# Altium schematic sheet MECHANICAL.SchDoc(MECHANICAL)
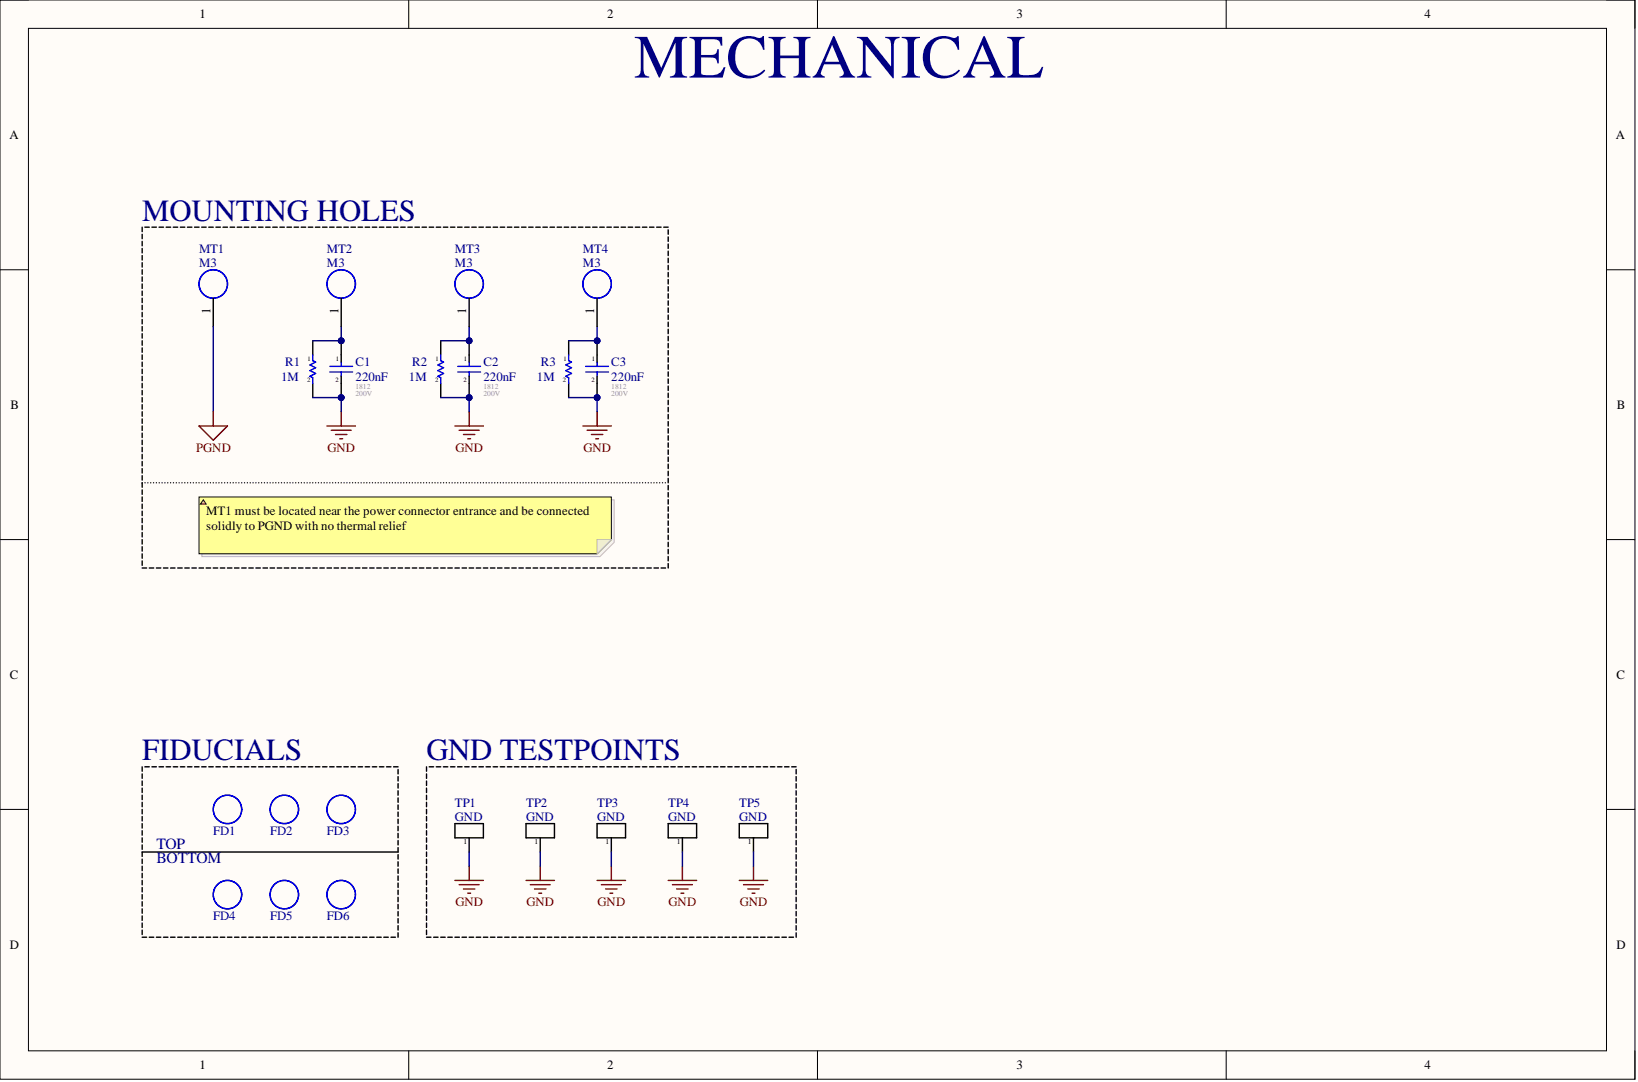
[diagram p0: MECHANICAL.SchDoc]

## Parts
C1  220nF  Voltage - Rated: 200V  [1812]
C2  220nF  Voltage - Rated: 200V  [1812]
C3  220nF  Voltage - Rated: 200V  [1812]
FD1  FIDUCIAL  [FIDUCIAL]  (no BOM)
FD2  FIDUCIAL  [FIDUCIAL]  (no BOM)
FD3  FIDUCIAL  [FIDUCIAL]  (no BOM)
FD4  FIDUCIAL  [FIDUCIAL]  (no BOM)
FD5  FIDUCIAL  [FIDUCIAL]  (no BOM)
FD6  FIDUCIAL  [FIDUCIAL]  (no BOM)
MT1  M3  [MTH_M3]
MT2  M3  [MTH_M3]
MT3  M3  [MTH_M3]
MT4  M3  [MTH_M3]
R1  1M  [RES_0402]
R2  1M  [RES_0402]
R3  1M  [RES_0402]
TP1  GND  [TP_HOLE]  (no BOM)
TP2  GND  [TP_HOLE]  (no BOM)
TP3  GND  [TP_HOLE]  (no BOM)
TP4  GND  [TP_HOLE]  (no BOM)
TP5  GND  [TP_HOLE]  (no BOM)

## Nets
GND: C1.2 C2.2 C3.2 R1.2 R2.2 R3.2 TP1.1 TP2.1 TP3.1 TP4.1 TP5.1
PGND: MT1.1
NetC1_1: C1.1 MT2.1 R1.1
NetC2_1: C2.1 MT3.1 R2.1
NetC3_1: C3.1 MT4.1 R3.1

## Library data of the parts
C1-C3 = CAP_CER: Generic SMT Ceramic Capacitor; Component Kind: Standard; ComponentLink1Description: Digikey; ComponentLink1URL: [Digikey Link]; ComponentLink2Description: Datasheet; ComponentLink2URL: [Datasheet Link]; Library Name: Symbols.SchLib
MT1-MT4 = MOUNTING_HOLE: Component Kind: Standard; Library Name: RaesLib.IntLib
R1-R3 = RESISTOR: Power: 1/16W; Tolerance: 10%; Component Kind: Standard; ComponentLink1Description: Digikey; Description: Resistor; Library Name: Symbols.SchLib; Package / Case: 0603
TP1-TP5 = TESTPOINT: Test Point; Library Name: Symbols.SchLib
FD1-FD6 = FIDUCIAL: Library Name: Symbols.SchLib
